# Altium schematic sheet OpAmp.SchDoc
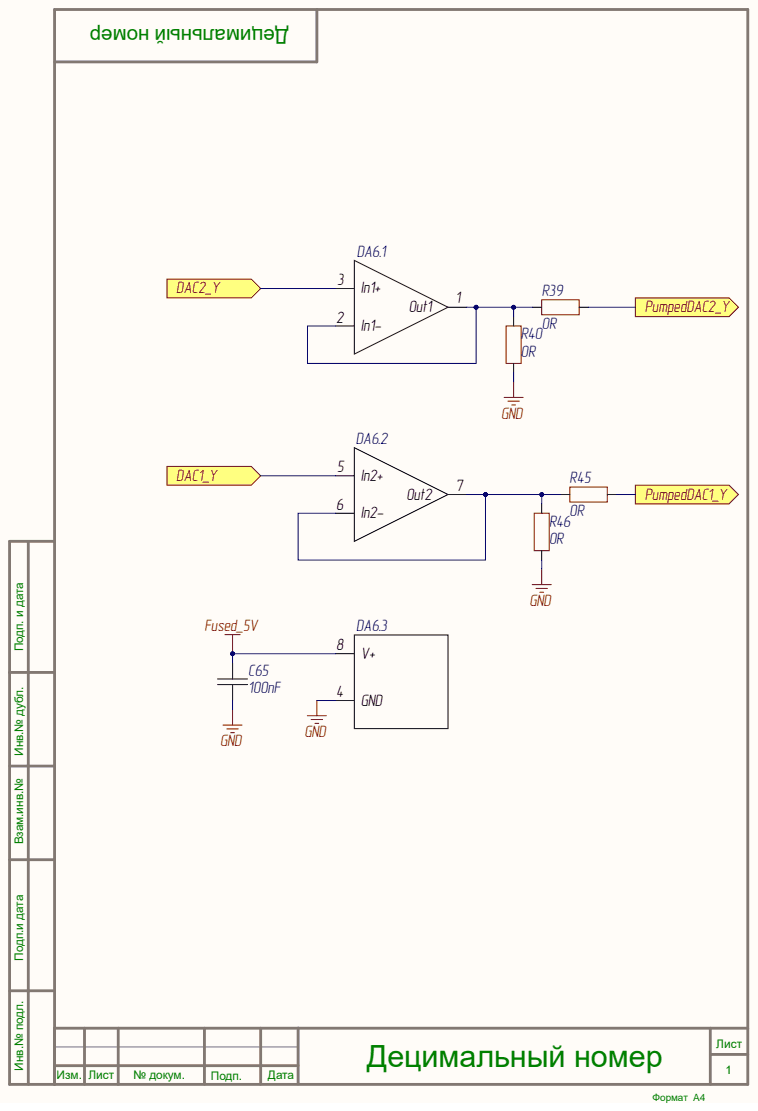
[diagram p0: OpAmp.SchDoc]

## Parts
C65  value 100nF  [CAP0402]  (hidden comment: 100nF 50V)
DA6  AD8032ARZ  [SOIC-8_M]  (value not drawn)  (parts .1,.2,.3)
R39  0R  [RESC0402(1005)_M]
R40  0R  [RESC0402(1005)_M]
R45  0R  [RESC0402(1005)_M]
R46  0R  [RESC0402(1005)_M]

## Ports
DAC1_Y, DAC2_Y, PumpedDAC1_Y, PumpedDAC2_Y

## Nets
Fused_5V: C65.2 DA6.8(V+)
GND: C65.1 DA6.4(GND) R40.1 R46.1
DAC1_Y: DA6.5(In2+) ; port DAC1_Y
DAC2_Y: DA6.3(In1+) ; port DAC2_Y
PumpedDAC1_Y: R45.1 ; port PumpedDAC1_Y
PumpedDAC2_Y: R39.1 ; port PumpedDAC2_Y
NetDA6_1: DA6.1(Out1) DA6.2(In1-) R39.2 R40.2
NetDA6_6: DA6.6(In2-) DA6.7(Out2) R45.2 R46.2

## Library data of the parts
C65 = CAP0402: Manufacturer: Samsung Electro-Mechanics; ManufacturerAlt: JLCPCB; MPN: CL05B104KB54PNC; MPNAlt: C307331; BOMDescription: SMD CHIP CERAMIC CAPACITOR CAP0402 100nF 10% 50V  CL05B104KB54PNC; Database Table Name: Symbol_SchLib; MntType: SMD; Notes: Basic Part; Tolerance: 10%; CFootprint: CAP0402; ClassName: Capacitor; Component Kind: Standard; ComponentLink1Description: Datasheet; ComponentLink1URL: https://datasheet.lcsc.com/lcsc/1810191222_Samsung-Electro-Mechanics-CL05B104KB54PNC_C307331.pdf; Height: 0.5mm; Library Name: Library.DbLib; MSL: MSL 1; Package: CAP0402; Part Number: CAP0402; PartType: CHIP CERAMIC CAPACITOR; Pins: 2; RoHS: Comply; Voltage: 50V; Weight: 1.500mg
DA6 = AD8032ARZ: Manufacturer: AD; BOMDescription: SMD Amplifier SOIC-8 =Value    AD8032ARZ; Database Table Name: Symbol_SchLib; MntType: SMD; CFootprint: SOIC-8_M; ClassName: Amplifier; Component Kind: Standard; ComponentLink1Description: Datasheet; ComponentLink1URL: https://eu.mouser.com/datasheet/2/609/AD8031_8032-1502047.pdf; Library Name: Library.DbLib; MPN: AD8032ARZ; MSL: MSL 1; Package: SOIC-8; Part Number: AD8032ARZ; PartType: Amplifier; Pins: 8; RoHS: Cpmply
R39 R40 R45 R46 = RES0402: BOMDescription: SMD CHIP RESISTOR RESC0402(1005)_M 0R; Database Table Name: Symbol_SchLib; MntType: SMD; CFootprint: RESC0402(1005)_M; ClassName: Resistor; Component Kind: Standard; ComponentLink1Description: Datasheet; Library Name: Library.DbLib; MSL: MSL 1; Package: RESC0402(1005)_M; Part Number: RES0402; PartType: CHIP RESISTOR; Pins: 2; RoHS: Comply; Value: 0R
